# Altium schematic sheet ESP32S.SchDoc
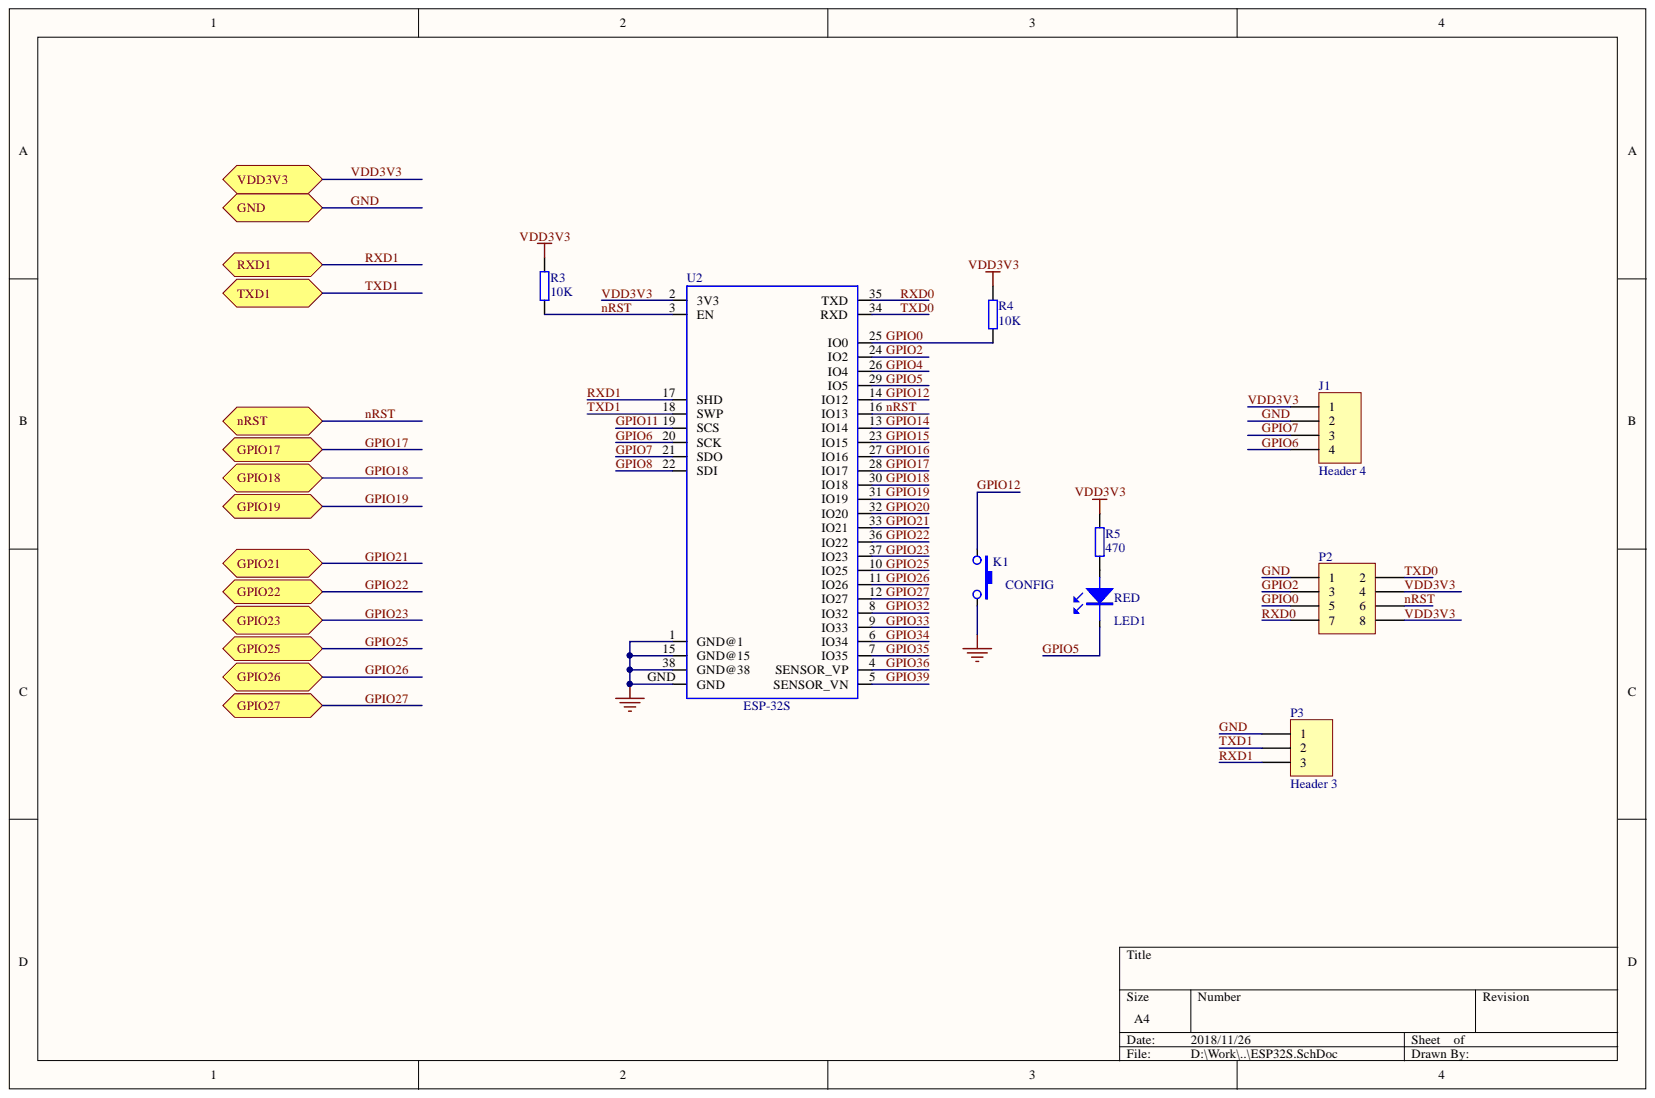
[diagram p0: ESP32S.SchDoc]

## Parts
J1  Header 4  [HDR1X4]
K1  CONFIG  [SW-2r9x4 - duplicate]
LED1  RED  [0603D]
P2  Header 4X2  [HDR2X4]  (value not drawn)
P3  Header 3  [HDR1X3]
R3  10K  [0603R]
R4  10K  [0603R]
R5  470  [0603R]
U2  ESP-32S  [ESP_32S]

## Ports
GND, GPIO17, GPIO18, GPIO19, GPIO21, GPIO22, GPIO23, GPIO25, GPIO26, GPIO27, NRST, RXD1, TXD1, VDD3V3

## Nets
GND: J1.2 K1.2 P2.1 P3.1 U2.1(GND@1) U2.15(GND@15) U2.38(GND@38) U2.GND ; port GND
GPIO0: P2.5 R4.1 U2.25(IO0)
GPIO2: P2.3 U2.24(IO2)
GPIO4: U2.26(IO4)
GPIO5: LED1.2 U2.29(IO5)
GPIO6: J1.4 U2.20(SCK)
GPIO7: J1.3 U2.21(SDO)
GPIO8: U2.22(SDI)
GPIO11: U2.19(SCS)
GPIO12: K1.1 U2.14(IO12)
GPIO14: U2.13(IO14)
GPIO15: U2.23(IO15)
GPIO16: U2.27(IO16)
GPIO17: U2.28(IO17) ; port GPIO17
GPIO18: U2.30(IO18) ; port GPIO18
GPIO19: U2.31(IO19) ; port GPIO19
GPIO20: U2.32(IO20)
GPIO21: U2.33(IO21) ; port GPIO21
GPIO22: U2.36(IO22) ; port GPIO22
GPIO23: U2.37(IO23) ; port GPIO23
GPIO25: U2.10(IO25) ; port GPIO25
GPIO26: U2.11(IO26) ; port GPIO26
GPIO27: U2.12(IO27) ; port GPIO27
GPIO32: U2.8(IO32)
GPIO33: U2.9(IO33)
GPIO34: U2.6(IO34)
GPIO35: U2.7(IO35)
GPIO36: U2.4(SENSOR_VP)
GPIO39: U2.5(SENSOR_VN)
NRST: P2.6 R3.1 U2.3(EN) U2.16(IO13) ; port NRST
RXD0: P2.7 U2.35(TXD)
RXD1: P3.3 U2.17(SHD) ; port RXD1
TXD0: P2.2 U2.34(RXD)
TXD1: P3.2 U2.18(SWP) ; port TXD1
VDD3V3: J1.1 P2.4 P2.8 R3.2 R4.2 R5.2 U2.2(3V3) ; port VDD3V3
NetLED1_1: LED1.1 R5.1

## Library data of the parts
J1 = Header 4: Header, 4-Pin; Component Kind: Standard; LatestRevisionDate: 17-Jul-2002; LatestRevisionNote: Re-released for DXP Platform.; Library Name: Miscellaneous Connectors.IntLib; Signal Integrity: Connector; Publisher: Altium Limited
K1 = SMT_SW_PTS_820: SMT Tactile ?Switch PTS 820 Series; Component Kind: Standard; Library Name: Vowstar_Switch.IntLib
LED1 = SMT_LED_0603: SMT LED; Component Kind: Standard; Library Name: Vowstar_Basic_Device.IntLib
P2 = Header 4X2: Header, 4-Pin, Dual row; Component Kind: Standard; LatestRevisionDate: 17-Jul-2002; LatestRevisionNote: Re-released for DXP Platform.; Library Name: Miscellaneous Connectors.IntLib; Signal Integrity: Connector; Publisher: Altium Limited
P3 = Header 3: Header, 3-Pin; Component Kind: Standard; LatestRevisionDate: 17-Jul-2002; LatestRevisionNote: Re-released for DXP Platform.; Library Name: Miscellaneous Connectors.IntLib; Signal Integrity: Connector; Publisher: Altium Limited
R3-R5 = SMT_R_0402: Surface mount resistor 0402; Component Kind: Standard; Library Name: Vowstar_Basic_Device.IntLib
U2 = ESP-WROOM-03: Component Kind: Standard; Library Name: esp32
